# Altium schematic sheet TOP.SchDoc(TOP)
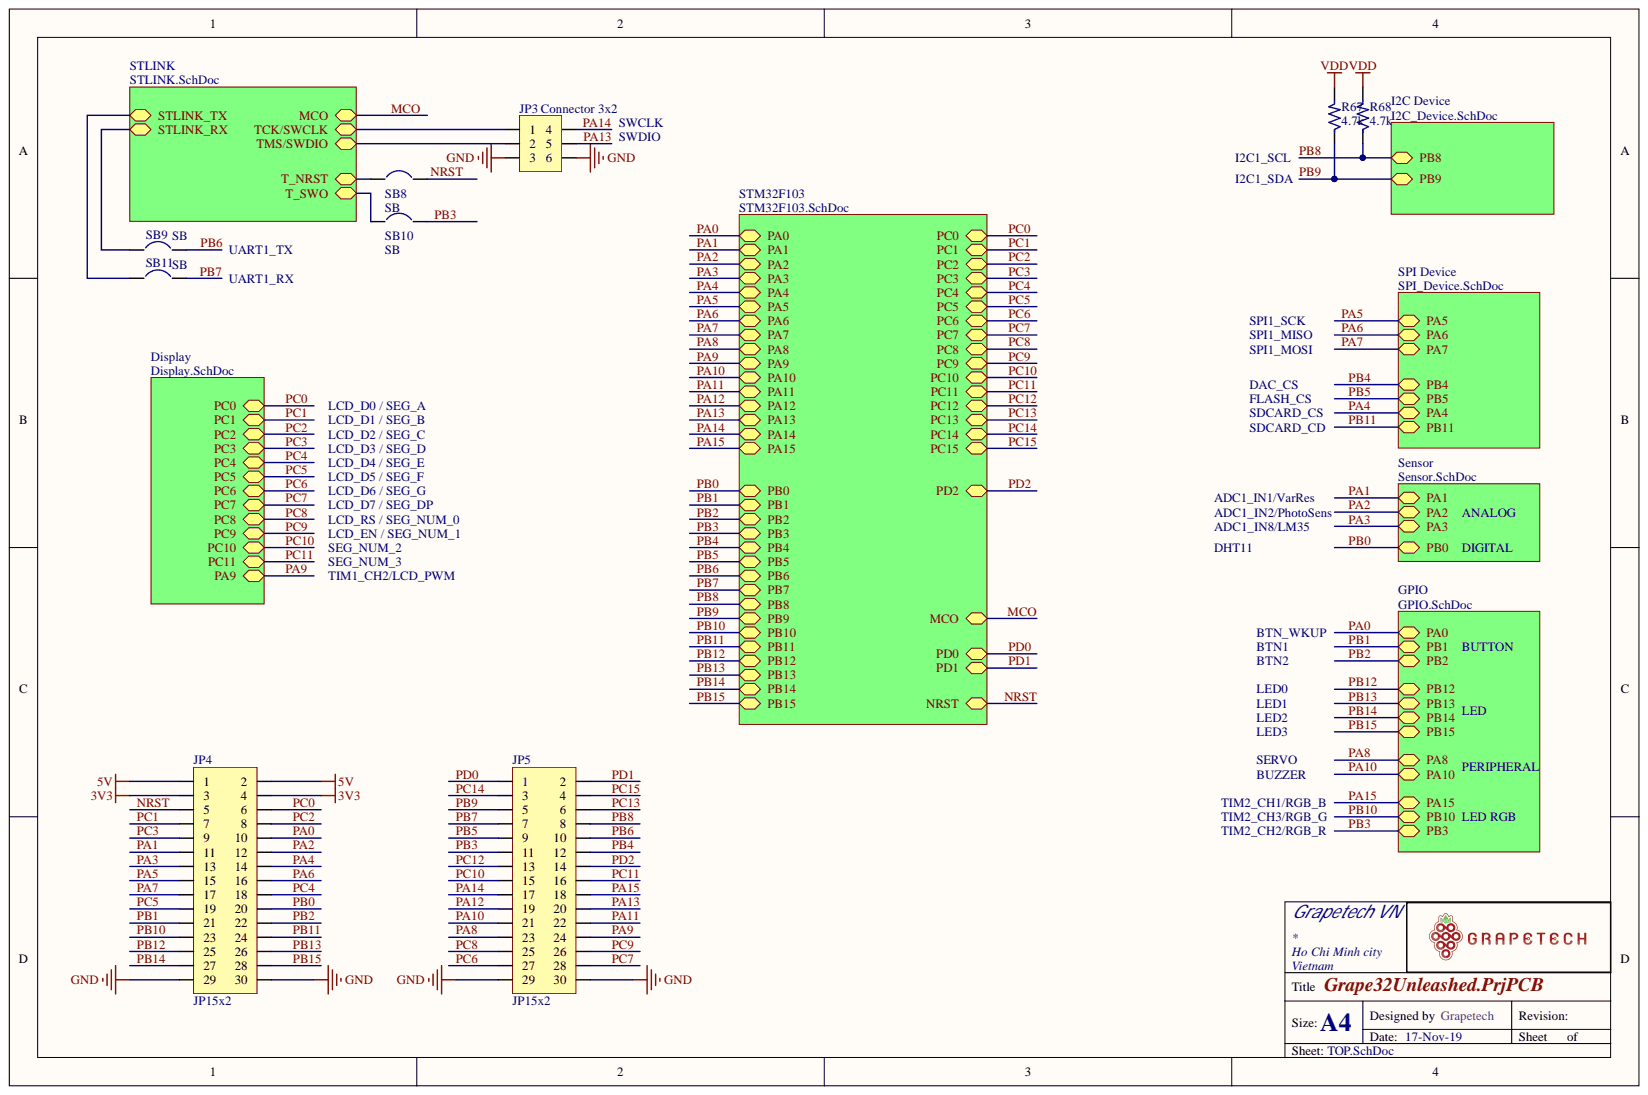
[diagram p0: TOP.SchDoc]

## Parts
JP3  Connector 3x2  [JP3x2]
JP4  JP15x2  [JP15x2]
JP5  JP15x2  [JP15x2]
R67  4.7k  [0402_res]
R68  4.7k  [0402_res]
SB8  SB  [SB0603]
SB9  SB  [SB0603]
SB10  SB  [SB0603]
SB11  SB  [SB0603]

## Nets
3V3: JP4.3 JP4.4
5V: JP4.1 JP4.2
GND: JP3.3 JP3.6 JP4.29 JP4.30 JP5.29 JP5.30
VDD: R67.2 R68.2
NRST: JP4.5 SB8.2
PA0: JP4.10
PA1: JP4.11
PA2: JP4.12
PA3: JP4.13
PA4: JP4.14
PA5: JP4.15
PA6: JP4.16
PA7: JP4.17
PA8: JP5.23
PA9: JP5.24
PA10: JP5.21
PA11: JP5.22
PA12: JP5.19
PA13: JP3.5 JP5.20
PA14: JP3.4 JP5.17
PA15: JP5.18
PB0: JP4.20
PB1: JP4.21
PB2: JP4.22
PB3: JP5.11 SB10.2
PB4: JP5.12
PB5: JP5.9
PB6: JP5.10 SB9.2
PB7: JP5.7 SB11.2
PB8: JP5.8 R68.1
PB9: JP5.5 R67.1
PB10: JP4.23
PB11: JP4.24
PB12: JP4.25
PB13: JP4.26
PB14: JP4.27
PB15: JP4.28
PC0: JP4.6
PC1: JP4.7
PC2: JP4.8
PC3: JP4.9
PC4: JP4.18
PC5: JP4.19
PC6: JP5.27
PC7: JP5.28
PC8: JP5.25
PC9: JP5.26
PC10: JP5.15
PC11: JP5.16
PC12: JP5.13
PC13: JP5.6
PC14: JP5.3
PC15: JP5.4
PD0: JP5.1
PD1: JP5.2
PD2: JP5.14
NetJP3_1: JP3.1
NetJP3_2: JP3.2
NetSB8_1: SB8.1
NetSB9_1: SB9.1
NetSB10_1: SB10.1
NetSB11_1: SB11.1
MCO:

## Library data of the parts
JP3 = JP3x2: Value: Connector 3x2; Library Name: MyLib.SchLib; Description: Connector 3x2; Component Kind: Standard
JP4 JP5 = JP15x2: 2.54mm pitch, 15x2 pin Connect sil female; Value: JP11; Library Name: MyLib.SchLib; Component Kind: Standard
R67 R68 = R: Resistor 1/4W; Value: R1/4W - 1K; Library Name: MyLib.SchLib; Component Kind: Standard
SB8-SB11 = SB: Library Name: MyLib.SchLib; Component Kind: Standard
